# Altium schematic sheet EVK-NORA-W4_SCHEM_0005_MikroE_ULED.SchDoc
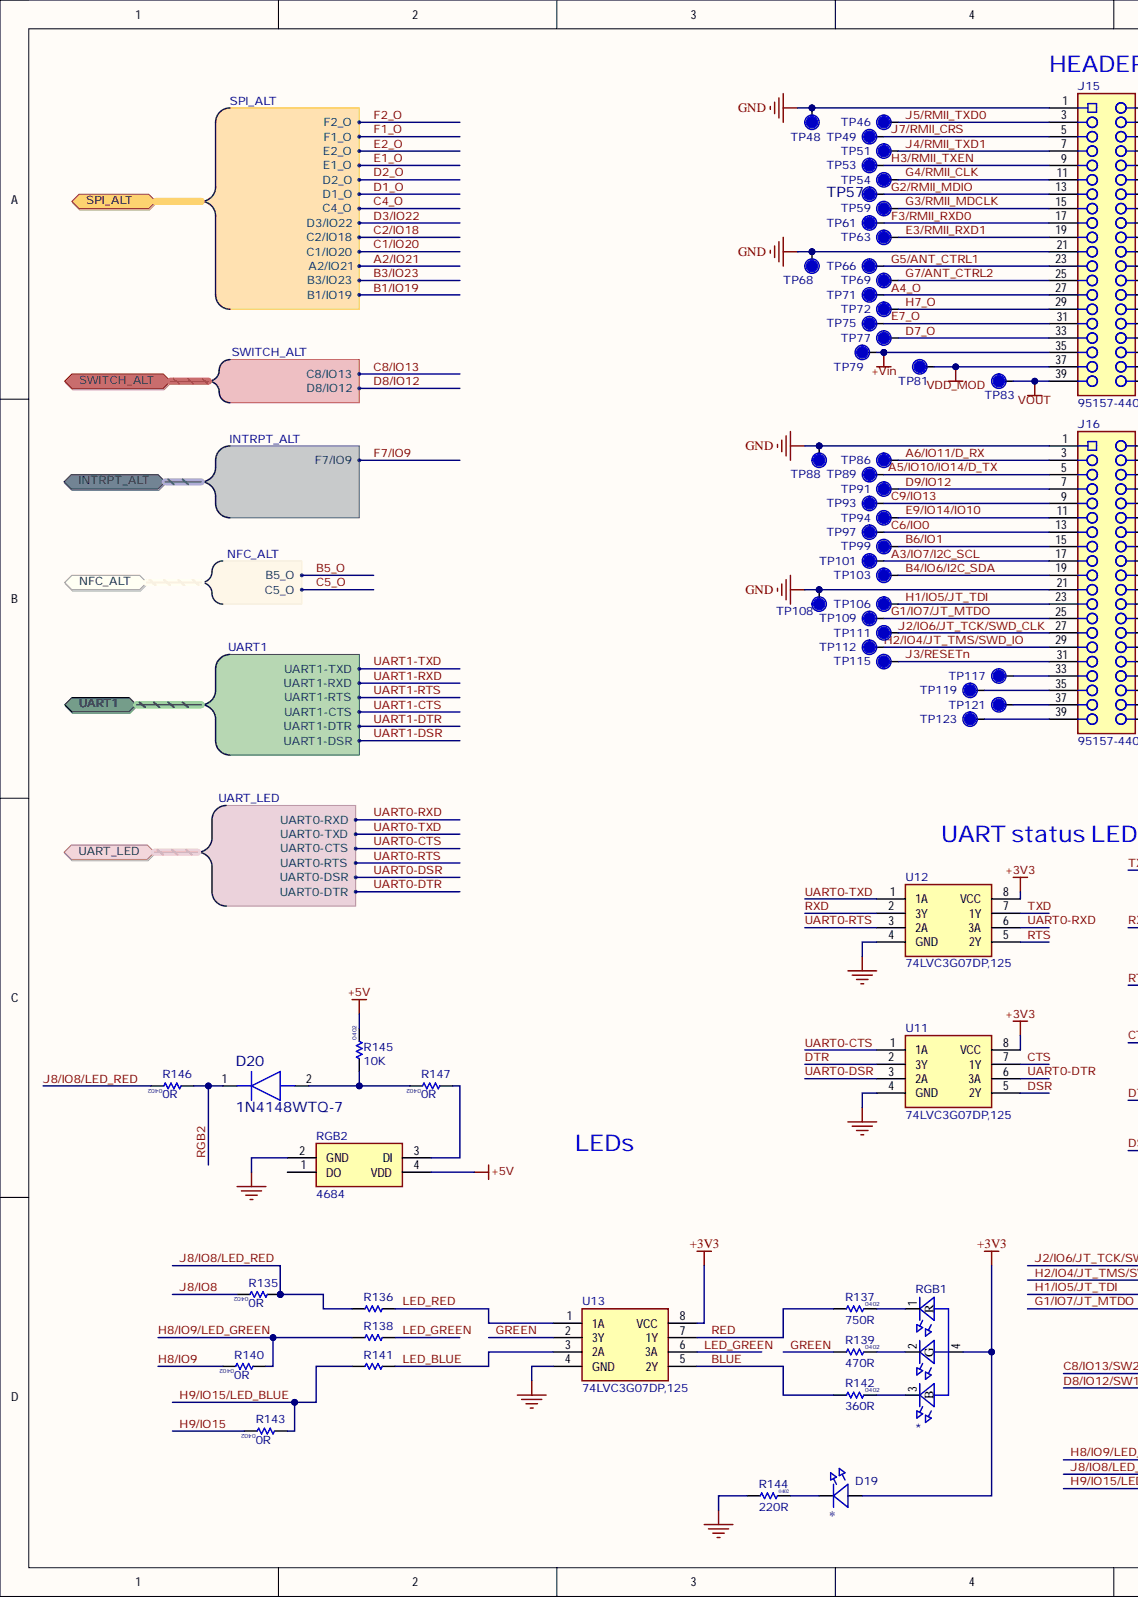
[diagram p0: EVK-NORA-W4_SCHEM_0005_MikroE_ULED.SchDoc, left]
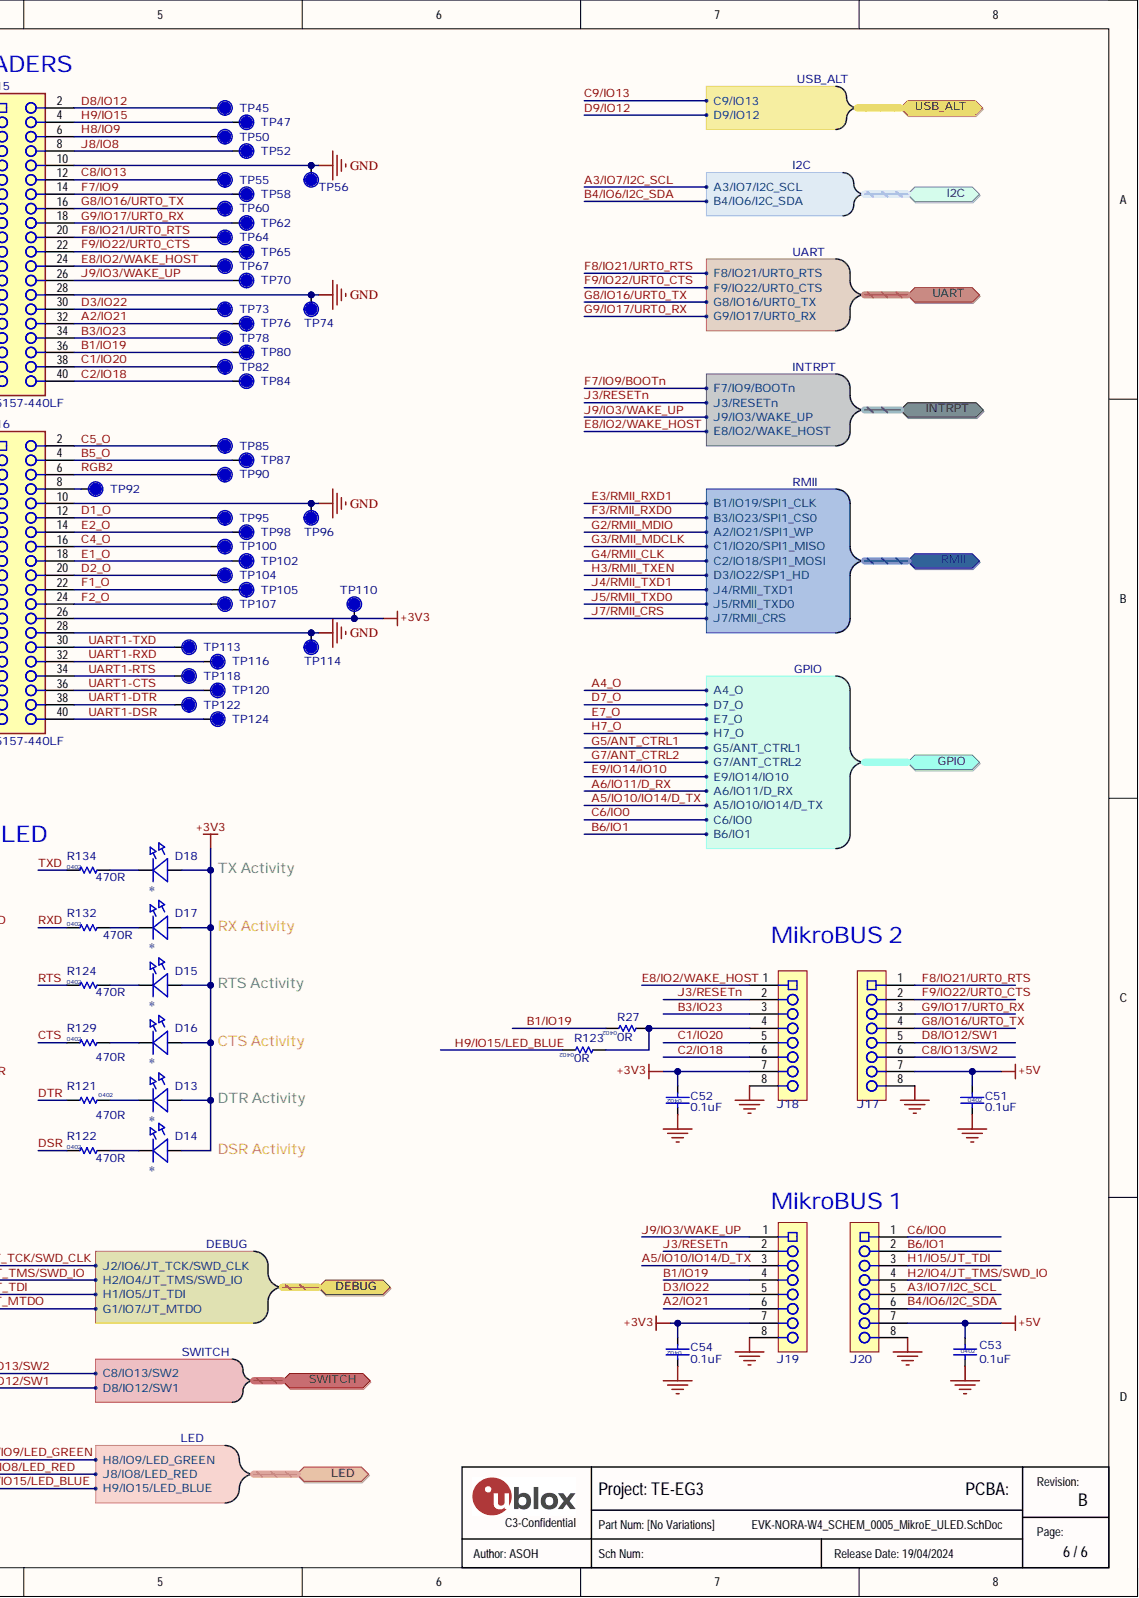
[diagram p1: EVK-NORA-W4_SCHEM_0005_MikroE_ULED.SchDoc, right]

## Parts
C51  value 0.1uF  Size: 0402  [Cap 0402 HD]  (hidden comment: 120-000160.1uF)
C52  value 0.1uF  Size: 0402  [Cap 0402 HD]  (hidden comment: 120-000160.1uF)
C53  value 0.1uF  Size: 0402  [Cap 0402 HD]  (hidden comment: 120-000160.1uF)
C54  value 0.1uF  Size: 0402  [Cap 0402 HD]  (hidden comment: 120-000160.1uF)
D13  UBXH11-0000001  [LED_0603_GREEN]  (value not drawn)
D14  UBXH11-0000057  [LED_0603_YELLOW]  (value not drawn)
D15  UBXH11-0000001  [LED_0603_GREEN]  (value not drawn)
D16  UBXH11-0000057  [LED_0603_YELLOW]  (value not drawn)
D17  UBXH11-0000057  [LED_0603_YELLOW]  (value not drawn)
D18  UBXH11-0000001  [LED_0603_GREEN]  (value not drawn)
D19  UBXH11-0000001  [LED_0603_GREEN]  (value not drawn)
D20  1N4148WTQ-7  [SODFL1608X65N]
J15  95157-440LF  [M208762042]
J16  95157-440LF  [M208762042]
J17  130-00102  [SKT-0.1P-SSM-108-L-SV]  (value not drawn)
J18  130-00102  [SKT-0.1P-SSM-108-L-SV]  (value not drawn)
J19  130-00102  [SKT-0.1P-SSM-108-L-SV]  (value not drawn)
J20  130-00102  [SKT-0.1P-SSM-108-L-SV]  (value not drawn)
R27  value 0R  Size: 0402  [RES 0402 HD]  (hidden comment: UBXH18-00030020R)
R121  value 470R  Size: 0402  [RES_0402_1100Lx600Wx400H_500x600Pad_400G_FLX]  (hidden comment: UBXH18-0008041470R)
R122  value 470R  Size: 0402  [RES_0402_1100Lx600Wx400H_500x600Pad_400G_FLX]  (hidden comment: UBXH18-0008041470R)
R123  value 0R  Size: 0402  [RES 0402 HD]  (hidden comment: UBXH18-00030020R)
R124  value 470R  Size: 0402  [RES_0402_1100Lx600Wx400H_500x600Pad_400G_FLX]  (hidden comment: UBXH18-0008041470R)
R129  value 470R  Size: 0402  [RES_0402_1100Lx600Wx400H_500x600Pad_400G_FLX]  (hidden comment: UBXH18-0008041470R)
R132  value 470R  Size: 0402  [RES_0402_1100Lx600Wx400H_500x600Pad_400G_FLX]  (hidden comment: UBXH18-0008041470R)
R134  value 470R  Size: 0402  [RES_0402_1100Lx600Wx400H_500x600Pad_400G_FLX]  (hidden comment: UBXH18-0008041470R)
R135  value 0R  Size: 0402  [RES 0402 HD]  (hidden comment: UBXH18-00030020R)
R136  UBXH18-00030020R  [RES 0402 HD]  (value not drawn)
R137  value 750R  Size: 0402  [RES_0402_1100Lx600Wx400H_500x600Pad_400G_FLX]  (hidden comment: UBXH18-0008046750R)
R138  UBXH18-00030020R  [RES 0402 HD]  (value not drawn)
R139  value 470R  Size: 0402  [RES_0402_1100Lx600Wx400H_500x600Pad_400G_FLX]  (hidden comment: UBXH18-0008041470R)
R140  value 0R  Size: 0402  [RES 0402 HD]  (hidden comment: UBXH18-00030020R)
R141  UBXH18-00030020R  [RES 0402 HD]  (value not drawn)
R142  value 360R  Size: 0402  [RES_0402_1100Lx600Wx400H_500x600Pad_400G_FLX]  (hidden comment: UBXH18-0000216360R)
R143  value 0R  Size: 0402  [RES 0402 HD]  (hidden comment: UBXH18-00030020R)
R144  value 220R  Size: 0402  [RES 0402 HD]  (hidden comment: UBXH18-0008033220R)
R145  value 10K  Size: 0402  [RES 0402 HD]  (hidden comment: UBXH18-000006510K)
R146  value 0R  Size: 0402  [RES 0402 HD]  (hidden comment: UBXH18-00030020R)
R147  value 0R  Size: 0402  [RES 0402 HD]  (hidden comment: UBXH18-00030020R)
RGB1  115-00026  [LED_1616]  (value not drawn)
RGB2  4684  [4684]
TP45  TP  [TP10R]  (no BOM)
TP46  TP  [TP10R]  (no BOM)
TP47  TP  [TP10R]  (no BOM)
TP48  TP  [TP10R]  (no BOM)
TP49  TP  [TP10R]  (no BOM)
TP50  TP  [TP10R]  (no BOM)
TP51  TP  [TP10R]  (no BOM)
TP52  TP  [TP10R]  (no BOM)
TP53  TP  [TP10R]  (no BOM)
TP54  TP  [TP10R]  (no BOM)
TP55  TP  [TP10R]  (no BOM)
TP56  TP  [TP10R]  (no BOM)
TP57  TP  [TP10R]
TP58  TP  [TP10R]  (no BOM)
TP59  TP  [TP10R]  (no BOM)
TP60  TP  [TP10R]  (no BOM)
TP61  TP  [TP10R]  (no BOM)
TP62  TP  [TP10R]  (no BOM)
TP63  TP  [TP10R]  (no BOM)
TP64  TP  [TP10R]  (no BOM)
TP65  TP  [TP10R]  (no BOM)
TP66  TP  [TP10R]  (no BOM)
TP67  TP  [TP10R]  (no BOM)
TP68  TP  [TP10R]  (no BOM)
TP69  TP  [TP10R]  (no BOM)
TP70  TP  [TP10R]  (no BOM)
TP71  TP  [TP10R]  (no BOM)
TP72  TP  [TP10R]  (no BOM)
TP73  TP  [TP10R]  (no BOM)
TP74  TP  [TP10R]  (no BOM)
TP75  TP  [TP10R]  (no BOM)
TP76  TP  [TP10R]  (no BOM)
TP77  TP  [TP10R]  (no BOM)
TP78  TP  [TP10R]  (no BOM)
TP79  TP  [TP10R]  (no BOM)
TP80  TP  [TP10R]  (no BOM)
TP81  TP  [TP10R]  (no BOM)
TP82  TP  [TP10R]  (no BOM)
TP83  TP  [TP10R]  (no BOM)
TP84  TP  [TP10R]  (no BOM)
TP85  TP  [TP10R]  (no BOM)
TP86  TP  [TP10R]  (no BOM)
TP87  TP  [TP10R]  (no BOM)
TP88  TP  [TP10R]  (no BOM)
TP89  TP  [TP10R]  (no BOM)
TP90  TP  [TP10R]  (no BOM)
TP91  TP  [TP10R]  (no BOM)
TP92  TP  [TP10R]  (no BOM)
TP93  TP  [TP10R]  (no BOM)
TP94  TP  [TP10R]  (no BOM)
TP95  TP  [TP10R]  (no BOM)
TP96  TP  [TP10R]  (no BOM)
TP97  TP  [TP10R]  (no BOM)
TP98  TP  [TP10R]  (no BOM)
TP99  TP  [TP10R]  (no BOM)
TP100  TP  [TP10R]  (no BOM)
TP101  TP  [TP10R]  (no BOM)
TP102  TP  [TP10R]  (no BOM)
TP103  TP  [TP10R]  (no BOM)
TP104  TP  [TP10R]  (no BOM)
TP105  TP  [TP10R]  (no BOM)
TP106  TP  [TP10R]  (no BOM)
TP107  TP  [TP10R]  (no BOM)
TP108  TP  [TP10R]  (no BOM)
TP109  TP  [TP10R]  (no BOM)
TP110  TP  [TP10R]  (no BOM)
TP111  TP  [TP10R]  (no BOM)
TP112  TP  [TP10R]  (no BOM)
TP113  TP  [TP10R]  (no BOM)
TP114  TP  [TP10R]  (no BOM)
TP115  TP  [TP10R]  (no BOM)
TP116  TP  [TP10R]  (no BOM)
TP117  TP  [TP10R]  (no BOM)
TP118  TP  [TP10R]  (no BOM)
TP119  TP  [TP10R]  (no BOM)
TP120  TP  [TP10R]  (no BOM)
TP121  TP  [TP10R]  (no BOM)
TP122  TP  [TP10R]  (no BOM)
TP123  TP  [TP10R]  (no BOM)
TP124  TP  [TP10R]  (no BOM)
U11  74LVC3G07DP,125  [SOP65P400X110-8N]
U12  74LVC3G07DP,125  [SOP65P400X110-8N]
U13  74LVC3G07DP,125  [SOP65P400X110-8N]

## Ports
DEBUG, DEBUG.G1/IO7/JT_MTDO, DEBUG.H1/IO5/JT_TDI, DEBUG.H2/IO4/JT_TMS/SWD_IO, DEBUG.J2/IO6/JT_TCK/SWD_CLK, GPIO, GPIO.A4_O, GPIO.A5/IO10/IO14/D_TX, GPIO.A6/IO11/D_RX, GPIO.B6/IO1, GPIO.C6/IO0, GPIO.D7_O, GPIO.E7_O, GPIO.E9/IO14/IO10, GPIO.G5/ANT_CTRL1, GPIO.G7/ANT_CTRL2, GPIO.H7_O, I2C, I2C.A3/IO7/I2C_SCL, I2C.B4/IO6/I2C_SDA, INTRPT, INTRPT.E8/IO2/WAKE_HOST, INTRPT.F7/IO9/BOOTn, INTRPT.J3/RESETn, INTRPT.J9/IO3/WAKE_UP, INTRPT_ALT, INTRPT_ALT.F7/IO9, LED, LED.H8/IO9/LED_GREEN, LED.H9/IO15/LED_BLUE, LED.J8/IO8/LED_RED, NFC_ALT, NFC_ALT.B5_O, NFC_ALT.C5_O, RMII, RMII.A2/IO21/SPI1_WP, RMII.B1/IO19/SPI1_CLK, RMII.B3/IO23/SPI1_CS0, RMII.C1/IO20/SPI1_MISO, RMII.C2/IO18/SPI1_MOSI, RMII.D3/IO22/SP1_HD, RMII.J4/RMII_TXD1, RMII.J5/RMII_TXD0, RMII.J7/RMII_CRS, SPI_ALT, SPI_ALT.A2/IO21, SPI_ALT.B1/IO19, SPI_ALT.B3/IO23, SPI_ALT.C1/IO20, SPI_ALT.C2/IO18, SPI_ALT.C4_O, SPI_ALT.D1_O, SPI_ALT.D2_O, SPI_ALT.D3/IO22, SPI_ALT.E1_O, SPI_ALT.E2_O, SPI_ALT.F1_O, SPI_ALT.F2_O, SWITCH, SWITCH.C8/IO13/SW2, SWITCH.D8/IO12/SW1, SWITCH_ALT, SWITCH_ALT.C8/IO13, SWITCH_ALT.D8/IO12, UART, UART1, UART1.UART1-CTS, UART1.UART1-DSR, UART1.UART1-DTR, UART1.UART1-RTS, UART1.UART1-RXD, UART1.UART1-TXD, UART.F8/IO21/URT0_RTS, UART.F9/IO22/URT0_CTS, UART.G8/IO16/URT0_TX, UART.G9/IO17/URT0_RX, UART_LED, UART_LED.UART0-CTS, UART_LED.UART0-DSR, UART_LED.UART0-DTR, UART_LED.UART0-RTS, UART_LED.UART0-RXD, UART_LED.UART0-TXD, USB_ALT, USB_ALT.C9/IO13, USB_ALT.D9/IO12

## Nets
+3V3: C52.2 C54.2 D13.2 D14.2 D15.2 D16.2 D17.2 D18.2 D19.2 J16.26 J18.7 J19.7 RGB1.4 TP110.1 U11.8(VCC) U12.8(VCC) U13.8(VCC)
+5V: C51.1 C53.1 J17.7 J20.7 R145.2 RGB2.4(VDD)
+Vin: J15.35 TP79.1
GND: C51.2 C52.1 C53.2 C54.1 J15.1 J15.10 J15.21 J15.28 J16.1 J16.10 J16.21 J16.28 J17.8 J18.8 J19.8 J20.8 R144.1 RGB2.2(GND) TP48.1 TP56.1 TP68.1 TP74.1 TP88.1 TP96.1 TP108.1 TP114.1 U11.4(GND) U12.4(GND) U13.4(GND)
VDD_MOD: J15.37 TP81.1
VOUT: J15.39 TP83.1
A2/IO21: J15.32 J19.6 TP76.1 ; port SPI_ALT
A3/IO7/I2C_SCL: J16.17 J20.5 TP101.1 ; port I2C
A4_O: J15.27 TP71.1 ; port GPIO
A5/IO10/IO14/D_TX: J16.5 J19.3 TP89.1 ; port GPIO
A6/IO11/D_RX: J16.3 TP86.1 ; port GPIO
B1/IO19: J15.36 J19.4 R27.2 TP80.1 ; port SPI_ALT
B3/IO23: J15.34 J18.3 TP78.1 ; port SPI_ALT
B4/IO6/I2C_SDA: J16.19 J20.6 TP103.1 ; port I2C
B5_O: J16.4 TP87.1 ; port NFC_ALT
B6/IO1: J16.15 J20.2 TP99.1 ; port GPIO
BLUE: R142.1 U13.5(2Y)
C1/IO20: J15.38 J18.5 TP82.1 ; port SPI_ALT
C2/IO18: J15.40 J18.6 TP84.1 ; port SPI_ALT
C4_O: J16.16 TP100.1 ; port SPI_ALT
C5_O: J16.2 TP85.1 ; port NFC_ALT
C6/IO0: J16.13 J20.1 TP97.1 ; port GPIO
C8/IO13: J15.12 TP55.1 ; port SWITCH_ALT
C8/IO13/SW2: J17.6 ; port SWITCH
C9/IO13: J16.9 TP93.1 ; port USB_ALT
CTS: R129.1 U11.7(1Y)
D1_O: J16.12 TP95.1 ; port SPI_ALT
D2_O: J16.20 TP104.1 ; port SPI_ALT
D3/IO22: J15.30 J19.5 TP73.1 ; port SPI_ALT
D7_O: J15.33 TP77.1 ; port GPIO
D8/IO12: J15.2 TP45.1 ; port SWITCH_ALT
D8/IO12/SW1: J17.5 ; port SWITCH
D9/IO12: J16.7 TP91.1 ; port USB_ALT
DSR: R122.1 U11.5(2Y)
DTR: R121.1 U11.2(3Y)
E1_O: J16.18 TP102.1 ; port SPI_ALT
E2_O: J16.14 TP98.1 ; port SPI_ALT
E3/RMII_RXD1: J15.19 TP63.1 ; port RMII
E7_O: J15.31 TP75.1 ; port GPIO
E8/IO2/WAKE_HOST: J15.24 J18.1 TP67.1 ; port INTRPT
E9/IO14/IO10: J16.11 TP94.1 ; port GPIO
F1_O: J16.22 TP105.1 ; port SPI_ALT
F2_O: J16.24 TP107.1 ; port SPI_ALT
F3/RMII_RXD0: J15.17 TP61.1 ; port RMII
F7/IO9: J15.14 TP58.1 ; port INTRPT_ALT
F8/IO21/URT0_RTS: J15.20 J17.1 TP64.1 ; port UART
F9/IO22/URT0_CTS: J15.22 J17.2 TP65.1 ; port UART
G1/IO7/JT_MTDO: J16.25 TP109.1 ; port DEBUG
G2/RMII_MDIO: J15.13 TP57.1 ; port RMII
G3/RMII_MDCLK: J15.15 TP59.1 ; port RMII
G4/RMII_CLK: J15.11 TP54.1 ; port RMII
G5/ANT_CTRL1: J15.23 TP66.1 ; port GPIO
G7/ANT_CTRL2: J15.25 TP69.1 ; port GPIO
G8/IO16/URT0_TX: J15.16 J17.4 TP60.1 ; port UART
G9/IO17/URT0_RX: J15.18 J17.3 TP62.1 ; port UART
GREEN: R139.1 U13.2(3Y)
H1/IO5/JT_TDI: J16.23 J20.3 TP106.1 ; port DEBUG
H2/IO4/JT_TMS/SWD_IO: J16.29 J20.4 TP112.1 ; port DEBUG
H3/RMII_TXEN: J15.9 TP53.1 ; port RMII
H7_O: J15.29 TP72.1 ; port GPIO
H8/IO9: J15.6 R140.2 TP50.1
H8/IO9/LED_GREEN: R138.2 R140.1 ; port LED
H9/IO15: J15.4 R143.2 TP47.1
H9/IO15/LED_BLUE: R123.2 R141.2 R143.1 ; port LED
J2/IO6/JT_TCK/SWD_CLK: J16.27 TP111.1 ; port DEBUG
J3/RESETn: J16.31 J18.2 J19.2 TP115.1 ; port INTRPT
J4/RMII_TXD1: J15.7 TP51.1 ; port RMII
J5/RMII_TXD0: J15.3 TP46.1 ; port RMII
J7/RMII_CRS: J15.5 TP49.1 ; port RMII
J8/IO8: J15.8 R135.2 TP52.1
J8/IO8/LED_RED: R135.1 R136.2 R146.2 ; port LED
J9/IO3/WAKE_UP: J15.26 J19.1 TP70.1 ; port INTRPT
LED_BLUE: R141.1 U13.3(2A)
LED_GREEN: R138.1 U13.6(3A)
LED_RED: R136.1 U13.1(1A)
RED: R137.1 U13.7(1Y)
RGB2: D20.1 J16.6 R146.1 TP90.1
RTS: R124.1 U12.5(2Y)
RXD: R132.1 U12.2(3Y)
TXD: R134.1 U12.7(1Y)
UART0-CTS: U11.1(1A) ; port UART_LED
UART0-DSR: U11.3(2A) ; port UART_LED
UART0-DTR: U11.6(3A) ; port UART_LED
UART0-RTS: U12.3(2A) ; port UART_LED
UART0-RXD: U12.6(3A) ; port UART_LED
UART0-TXD: U12.1(1A) ; port UART_LED
UART1-CTS: J16.36 TP120.1 ; port UART1
UART1-DSR: J16.40 TP124.1 ; port UART1
UART1-DTR: J16.38 TP122.1 ; port UART1
UART1-RTS: J16.34 TP118.1 ; port UART1
UART1-RXD: J16.32 TP116.1 ; port UART1
UART1-TXD: J16.30 TP113.1 ; port UART1
NetD13_1: D13.1 R121.2
NetD14_1: D14.1 R122.2
NetD15_1: D15.1 R124.2
NetD16_1: D16.1 R129.2
NetD17_1: D17.1 R132.2
NetD18_1: D18.1 R134.2
NetD19_1: D19.1 R144.2
NetD20_2: D20.2 R145.1 R147.2
NetJ16_8: J16.8 TP92.1
NetJ16_33: J16.33 TP117.1
NetJ16_35: J16.35 TP119.1
NetJ16_37: J16.37 TP121.1
NetJ16_39: J16.39 TP123.1
NetJ18_4: J18.4 R27.1 R123.1
NetR137_2: R137.2 RGB1.1(R)
NetR139_2: R139.2 RGB1.2(G)
NetR142_2: R142.2 RGB1.3(B)
NetR147_1: R147.1 RGB2.3(DI)
F7/IO9/BOOTn: ; port INTRPT

Not connected: RGB2 1(DO)

## Library data of the parts
C51-C54 = 120-00016: Cap, Ceramic 0.1uF 10V 10% X5R 0402; Manufacturer: Murata Electronics North America; Manufacturer P/N: GRM155R61A104KA01J; Part Name: CAP CER 0.1UF 10V 10% X5R 0402; Component Mounting Type: SMT; Database Table Name: Ceramic Capacitor; Item_Phase: Production; Tolerance: +/- 10%; Use in new designs: Yes; Arena Link: https://app.bom.com/a/GYHPV2L2L43KRMBK?v=spec.summary; Component Kind: Standard; Height: 0.55mm; Manufacturer 2: Yageo; Manufacturer P/N 2: CC0402KRX5R6BB104; Part Number: 120-00016; Part_Type: Ceramic Capacitor; Pins: 2; Revision: 0A; Signal Integrity: Cap Semi; Simulation: CAP; Supplier 1: Digi-Key; Supplier 2: Digi-Key; Supplier Part Number 1: 490-6297-1-ND; Supplier Part Number 2: 311-1336-1-ND; Temperature Coefficient: X5R; Voltage: 10V; Library Name: Arena_Parts_DB_Lib.DbLib
D13 D15 D18 D19 = UBXH11-0000001: LED SMD 0603 GREEN 2.5V 20mA; Manufacturer: Kingbright; Manufacturer P/N: APT1608SGC; Database Table Name: Discrete - LED; Editor: gianni.falleroni; Env: ROHS; Mfg P/N Released: Yes; Mfg P/N Split: 50; Mfg P/N Status: Active; Permitted Use: Support Products; Released by: alex.claville; Component Kind: Standard; Library Name: UBX_Parts_DB_Lib.DbLib; Manufacturer 2: Lite-on Technology Corporation; Manufacturer P/N 2: LTST-C190KGKT; Max Current: 20mA; Max Voltage: 2.5V; Mfg P/N Datasheet: https://wiki.u-blox.com/bin/view/Generic/Docs/DocumentSummary?index=37527; Mfg P/N Datasheet 2: https://wiki.u-blox.com/bin/view/Generic/Docs/DocumentSummary?index=16220; Mfg P/N Released 2: Yes; Mfg P/N Split 2: 50; Mfg P/N Status 2: Active; Part Number: UBXH11-0000001; Part Type: LED; Perferred: N/A; RefDes: DS; Release Data: 2022-09-27T14:26:54; Size: 0603; UBX Wiki: https://wiki.u-blox.com/bin/view/Operations/PartDetails?index=7902; Value: GREEN
D14 D16 D17 = UBXH11-0000057: DIODE LED SMT YELLOW CLEAR LENS 2.1V 10MA 0603 YELLOW; Manufacturer: Lite-on Technology Corporation; Manufacturer P/N: LTST-C190YKT; Database Table Name: Discrete - LED; Editor: eric.moog; Env: GREEN; Mfg P/N Released: No; Mfg P/N Split: 100; Mfg P/N Status: Active; Permitted Use: Volume Products; Component Kind: Standard; Library Name: UBX_Parts_DB_Lib.DbLib; Max Operating Temp: 85°C; Mfg P/N Datasheet: https://wiki.u-blox.com/bin/view/Generic/Docs/DocumentSummary?index=38122; Min Operating Temp: -55°C; Part Number: UBXH11-0000057; Part Type: LED; Perferred: N/A; RefDes: DS; Size: 0603; UBX Wiki: https://wiki.u-blox.com/bin/view/Operations/PartDetails?index=38120; Value: YELLOW
D20 = 1N4148WTQ-7: Diode; Manufacturer_Name: Diodes Incorporated; Arrow Part Number: 1N4148WTQ-7; Arrow Price/Stock: https://www.arrow.com/en/products/1n4148wtq-7/diodes-incorporated?region=europe; Component Kind: Standard; Datasheet Link: https://datasheet.datasheetarchive.com/originals/distributors/Datasheets_SAMA/6cd1b04b95e93ddd69dba57393c402aa.pdf; Height: 0.65mm; Manufacturer_Part_Number: 1N4148WTQ-7; Mouser Part Number: 621-1N4148WTQ-7; Mouser Price/Stock: https://www.mouser.co.uk/ProductDetail/Diodes-Incorporated/1N4148WTQ-7?qs=vdQOMtKMWaCrKb90MkA6xQ%3D%3D
J15 J16 = M20-8762042: Connector; Manufacturer_Name: Amphenol; Component Kind: Standard; Height: 10.01mm; Library Name: SamacSys.SchLib; Manufacturer_Part_Number: 95157-440LF
  J16: Mouser Price/Stock: https://www.mouser.co.uk/ProductDetail/Harwin/M20-8762042?qs=vF78I%252BjhbY%2F5WnwPzhFszw%3D%3D
J17-J20 = 130-00102: Socket Strip 0.1" 8 Pin 1Row SMD Black; Manufacturer 1: Samtec; Manufacturer Part Number 1: SSM-108-F-SV-P-TR; Part Name: CON SKT Strip 0.1" 8Pin 1Row SMD Black; Database Table Name: Connector; Item Phase: In Production; UBX Code: None; Use in new designs: Yes; Voltage: 572VDC; Component Kind: Standard; Diameter: None; Height: 7.37mm; Manufacturer 2: Sullins Connector Solutions; Manufacturer Part Number 2: NPPC081KFXC-RC; Max Operating Temp: 105; Min Operating Temp: -55; Part Number: 130-00102; Pins: 8; Revision: 0D; Supplier 1: Avnet; Supplier 2: Digi-Key; Supplier Part Number 1: SSM-108-F-SV-P-TR; Supplier Part Number 2: S5639-ND; Library Name: Arena_Parts_DB_Lib.DbLib
R27 R123 R135 R136 R138 R140 R141 R143 R146 R147 = UBXH18-0003002: RES THICK FILM CHIP 0402 0R 0 100mW; Manufacturer: KOA; Manufacturer P/N: RK73Z1ETTP; Database Table Name: Passive - Resistor; Editor: charlotte.biber; Env: ROHS; Mfg P/N Released: Yes; Mfg P/N Split: 100; Mfg P/N Status: NRND; Perferred: PREF; Permitted Use: Volume Products; Released by: peter.mueller; Tolerance: 0; Component Kind: Standard; Library Name: UBX_Parts_DB_Lib.DbLib; Manufacturer 2: YAGEO; Manufacturer P/N 2: 2322_705_91001_L; Max Power: 100mW; Mfg P/N Released 2: Yes; Mfg P/N Split 2: 0; Mfg P/N Status 2: NRND; Part Number: UBXH18-0003002; Part Type: Resistor; RefDes: R; Release Data: 2012-06-05 09:49:55.978616; Signal Integrity: Res; Simulation: RESISTOR; UBX Wiki: https://wiki.u-blox.com/bin/view/Operations/PartDetails?index=6611
  R136 R138 R141: Size: 0402; Value: 0R
R121 R122 R124 R129 R132 R134 R139 = UBXH18-0008041: RES THICK FILM CHIP 0402 470R 5% 100mW; Manufacturer: ROHM; Manufacturer P/N: MCR01MZPJ471; Database Table Name: Passive - Resistor; Editor: roland.rust; Env: ROHS; Mfg P/N Released: Yes; Mfg P/N Split: 100; Mfg P/N Status: Obsolete; Perferred: PREF; Permitted Use: Volume Products; Component Kind: Standard; Library Name: UBX_Parts_DB_Lib.DbLib; Manufacturer 2: KOA; Manufacturer 3: YAGEO; Manufacturer P/N 2: RK73B1ETTP471J; Manufacturer P/N 3: 2322_705_87471_L; Max Power: 100mW; Mfg P/N Released 2: Yes; Mfg P/N Released 3: Yes; Mfg P/N Split 2: 0; Mfg P/N Split 3: 0; Mfg P/N Status 2: Active; Mfg P/N Status 3: Obsolete; Part Number: UBXH18-0008041; Part Type: Resistor; RefDes: R; Release Data: 2009-04-24T14:36:39; Signal Integrity: Res; Simulation: RESISTOR; Tolerance: 5%; UBX Wiki: https://wiki.u-blox.com/bin/view/Operations/PartDetails?index=6926; Released by: roland.rust
R137 = UBXH18-0008046: RES THICK FILM CHIP 0402 750R 5% 100mW; Manufacturer: Panasonic; Manufacturer P/N: ERJ2GEJ751X; Database Table Name: Passive - Resistor; Editor: jaka.bevk; Env: ROHS; Mfg P/N Released: Yes; Mfg P/N Split: 100; Mfg P/N Status: Active; Perferred: PREF; Permitted Use: Volume Products; Released by: erian.bezhani; Component Kind: Standard; Library Name: UBX_Parts_DB_Lib.DbLib; Max Power: 100mW; Mfg P/N Datasheet: https://wiki.u-blox.com/bin/view/Generic/Docs/DocumentSummary?index=21267; Part Number: UBXH18-0008046; Part Type: Resistor; RefDes: R; Release Data: 2014-10-03T09:31:33; Signal Integrity: Res; Simulation: RESISTOR; Tolerance: 5%; UBX Wiki: https://wiki.u-blox.com/bin/view/Operations/PartDetails?index=6935
R142 = UBXH18-0000216: RES THICK FILM CHIP 0402 360R 1% 63mW; Manufacturer: KOA; Manufacturer P/N: RK73H1ETTP3600F; Database Table Name: Passive - Resistor; Editor: michael.hein; Env: ROHS; Mfg P/N Released: Yes; Mfg P/N Split: 100; Mfg P/N Status: Active; Perferred: PREF; Permitted Use: Volume Products; Released by: vasyl.dykyy; Automotive: Yes; Component Kind: Standard; Library Name: UBX_Parts_DB_Lib.DbLib; Max Power: 63mW; Mfg P/N Datasheet: https://wiki.u-blox.com/bin/view/Generic/Docs/DocumentSummary?index=8222; Part Number: UBXH18-0000216; Part Type: Resistor; RefDes: R; Release Data: 2015-10-02T11:55:45; Signal Integrity: Res; Simulation: RESISTOR; Tolerance: 1%; UBX Wiki: https://wiki.u-blox.com/bin/view/Operations/PartDetails?index=23306
R144 = UBXH18-0008033: RES THICK FILM CHIP 0402 220R 5% 63mW; Manufacturer: KOA; Manufacturer P/N: RK73B1ETTP221J; Database Table Name: Passive - Resistor; Editor: alvaro.nussbaum; Env: ROHS; Mfg P/N Released: Yes; Mfg P/N Split: 100; Mfg P/N Status: Active; Perferred: PREF; Permitted Use: Volume Products; Released by: martin.pilik; Component Kind: Standard; Library Name: UBX_Parts_DB_Lib.DbLib; Manufacturer 2: YAGEO; Manufacturer 3: ROHM; Manufacturer P/N 2: RC0402JR-13220RL; Manufacturer P/N 3: MCR01MZPJ221; Max Power: 63mW; Mfg P/N Datasheet: https://wiki.u-blox.com/bin/view/Generic/Docs/DocumentSummary?index=39896; Mfg P/N Datasheet 2: https://wiki.u-blox.com/bin/view/Generic/Docs/DocumentSummary?index=26941; Mfg P/N Released 2: Yes; Mfg P/N Released 3: Yes; Mfg P/N Split 2: 0; Mfg P/N Split 3: 0; Mfg P/N Status 2: Active; Mfg P/N Status 3: Obsolete; Part Number: UBXH18-0008033; Part Type: Resistor; RefDes: R; Release Data: 2024-02-26T15:01:33; Signal Integrity: Res; Simulation: RESISTOR; Tolerance: 5%; UBX Wiki: https://wiki.u-blox.com/bin/view/Operations/PartDetails?index=6914
R145 = UBXH18-0000065: RES THICK FILM CHIP 0402 10K 1% 63mW; Manufacturer: KOA; Manufacturer P/N: RK73H1ETTP1002F; Database Table Name: Passive - Resistor; Editor: erian.bezhani; Env: ROHS; Mfg P/N Released: Yes; Mfg P/N Split: 100; Mfg P/N Status: Active; Perferred: PREF; Permitted Use: Volume Products; Released by: massimo.vlacci; Component Kind: Standard; Library Name: UBX_Parts_DB_Lib.DbLib; Max Power: 63mW; Mfg P/N Datasheet: https://wiki.u-blox.com/bin/view/Generic/Docs/DocumentSummary?index=8222; Part Number: UBXH18-0000065; Part Type: Resistor; RefDes: R; Release Data: 2012-06-08 18:02:21.521517; Signal Integrity: Res; Simulation: RESISTOR; Tolerance: 1%; UBX Wiki: https://wiki.u-blox.com/bin/view/Operations/PartDetails?index=16837
RGB1 = 115-00026: LED RGB SMT 0606; Manufacturer 1: Lite-On Inc; Manufacturer Part Number 1: LTST-C19HE1WT; Body Size: 1.6mm x 1.6mm; Current: 20mA; Item Phase: In Production; MFG Package: 0606; UBX Code: None; Use in new designs: Yes; Component Kind: Standard; Database Table Name: LED; Height: 0.35mm; Max Operating Temp: 80; Min Operating Temp: -20; Part Name: LED RGB SMT 0606; Part Number: 115-00026; Pins: 4; Revision: 0B; Size: Other; Supplier 1: Digi-Key; Supplier 2: Digi-Key; Supplier Part Number 1: 160-2162-1-ND; Supplier Part Number 2: 1516-1184-1-ND; Voltage: None; Library Name: Arena_Parts_DB_Lib.DbLib
RGB2 = 4684: LED; Manufacturer_Name: Adafruit; Arrow Part Number: 4684; Arrow Price/Stock: https://www.arrow.com/en/products/4684/adafruit-industries?region=nac; Component Kind: Standard; Datasheet Link: https://cdn-shop.adafruit.com/product-files/4684/4684_WS2812B-2020_V1.3_EN.pdf; Height: 0.84mm; Library Name: SamacSys.SchLib; Manufacturer_Part_Number: 4684; Mouser Part Number: 485-4684; Mouser Price/Stock: https://www.mouser.co.uk/ProductDetail/Adafruit/4684?qs=DPoM0jnrROWIv9%2FMCIm5vw%3D%3D
TP45-TP124 = TP10R: Testpoint, Round 1.0mm Dia; Added By: Jeremy D; Database Table Name: Testpoints & Pads; DNP: DNP; Height: 0; Part Number: TP10R; Size: 1.0mm; Checked By: Paul B; Library Name: LEGACY_Rigado_AltiumLib.DbLib
  TP45-TP56 TP58-TP124: Added Date: 2/1/2015; Checked Date: 2/5/2015
  TP57: Added Date: 2015-02-01; Checked Date: 2015-02-05; Component Kind: Standard
U11-U13 = 74LVC3G07DP,125: Integrated Circuit; Manufacturer_Name: Nexperia; Arrow Part Number: 74LVC3G07DP,125; Arrow Price/Stock: https://www.arrow.com/en/products/74lvc3g07dp125/nexperia?region=nac; Component Kind: Standard; Datasheet Link: https://assets.nexperia.com/documents/data-sheet/74LVC3G07.pdf; Height: 1.1mm; Manufacturer_Part_Number: 74LVC3G07DP,125; Mouser Part Number: 771-74LVC3G07DP-G; Mouser Price/Stock: https://www.mouser.co.uk/ProductDetail/Nexperia/74LVC3G07DP125?qs=me8TqzrmIYXM25jpSFl3Hg%3D%3D
